# Altium schematic sheet I2C_PORT.Sch
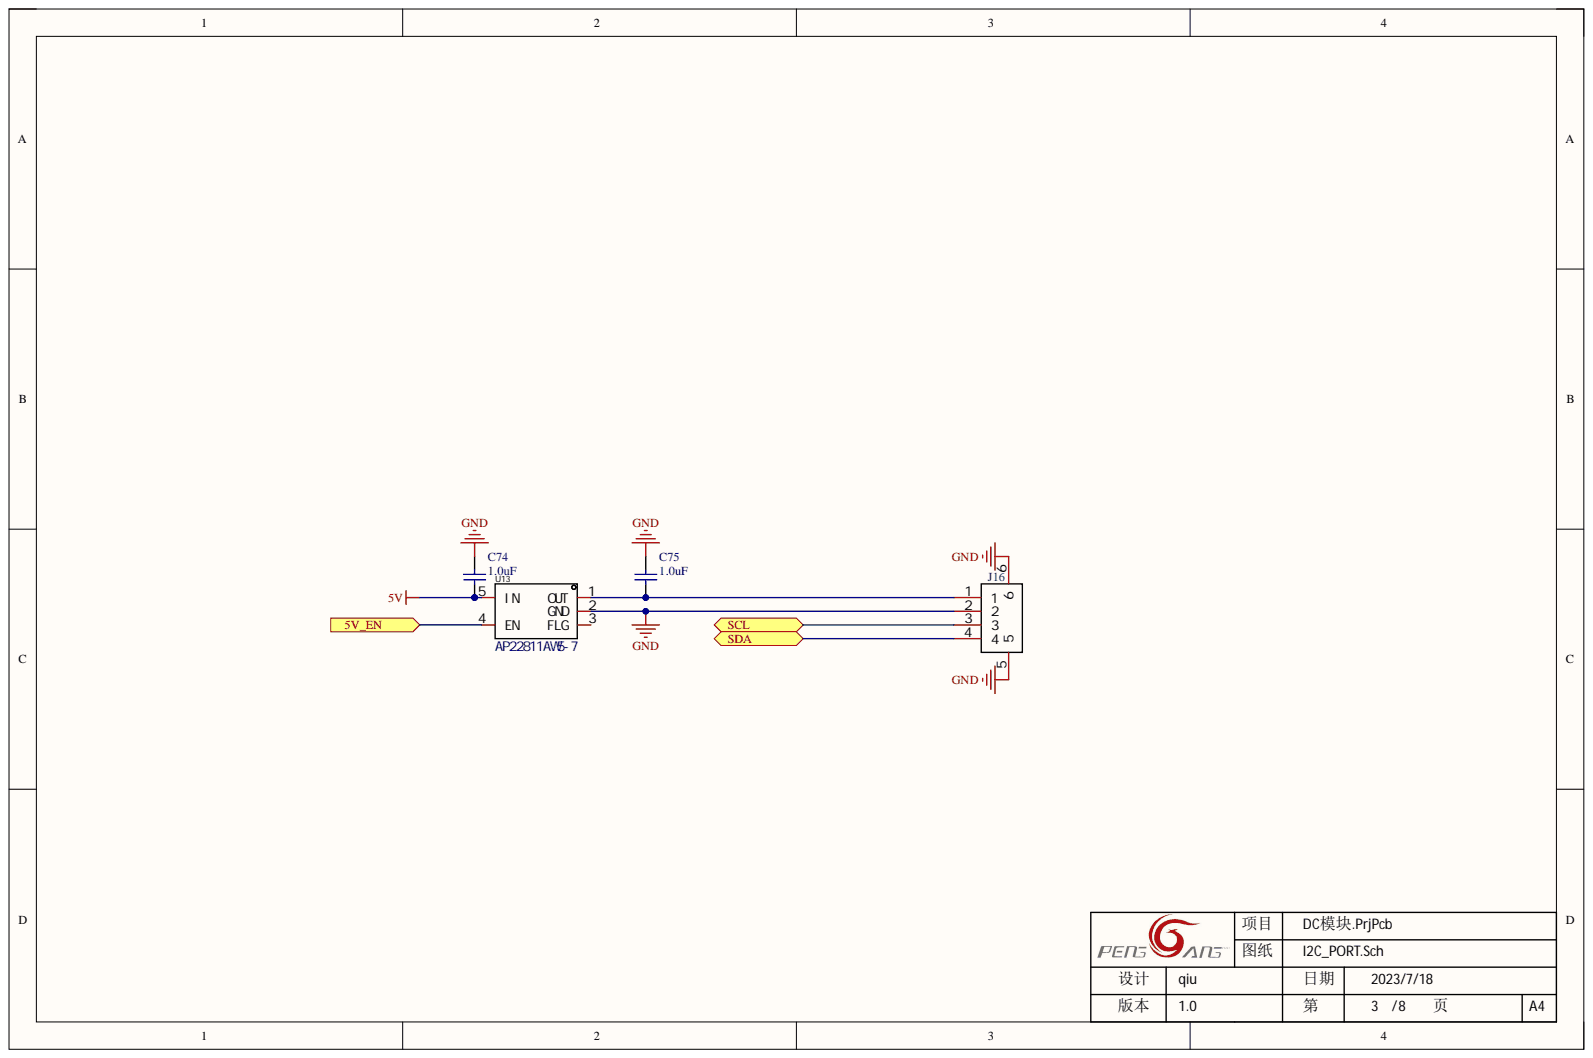
[diagram p0: I2C_PORT.Sch]

## Parts
C74  1.0uF  [0402_C]
C75  1.0uF  [0402_C]
J16  SM04B-GHS-TB(LF)(SN)  [CONN-SMD_4P-P1.25_SM04B-GHS-TB-LF-SN]  (value not drawn)
U13  AP22811AW5-7  [SOT-25-5_L3.0-W1.6-P0.95-LS2.8-BL]

## Ports
5V_EN, SCL, SDA

## Nets
5V: C74.2 U13.5(IN)
GND: C74.1 C75.1 J16.2 J16.5 J16.6 U13.2(GND)
5V_EN: U13.4(EN) ; port 5V_EN
SCL: J16.3 ; port SCL
SDA: J16.4 ; port SDA
NetC75_2: C75.2 J16.1 U13.1(OUT)

Not connected: U13 3(FLG)

## Library data of the parts
C74 C75 = 0402 1.0uF (105) 10% 25V: 贴片电容; Component Kind: Standard; ComponentLink1Description: 供应商链接; ComponentLink1URL: http://www.szlcsc.com/product/details_53938.html; Library Name: Miscellaneous Devices LC.IntLib; Package: 0402; Supplier: LC; SuppliersPartNumber: C52923
J16 = GH_SM04B-GHS-TB(LF)(SN): Manufacturer: JST; Add into BOM: yes; Number of PINs Per Row: 4; Pins Structure: 1x4P; Pitch: 1.25mm; Reference Series: GH; Component Kind: Standard; ComponentLink1Description: 供应商链接; ComponentLink1URL: https://item.szlcsc.com/207060.html; Contact Material: 磷青铜; Convert to PCB: yes; Datasheet: https://atta.szlcsc.com/upload/public/pdf/source/20180327/C189895_E152F1273677DB005E39DD42455B7B10.pdf; Device: SM04B-GHS-TB(LF)(SN); JLCPCB Part Class: Extended Part; LCSC Part Name: GH 1.25mm 1x4P; Library Name: mylib.SchLib; Manufacturer Part: SM04B-GHS-TB(LF)(SN); Mounting Style: -; Name: SM04B-GHS-TB(LF)(SN); Number of Rows: 1; Row Spacing: -; Supplier: LCSC; Supplier Footprint: SMD,P=1.25mm; SuppliersPartNumber: C189895; Symbol: SM04B-GHS-TB(LF)(SN)
U13 = AP22811AW5-7: Component Kind: Standard; ComponentLink1Description: 供应商链接; ComponentLink1URL: https://item.szlcsc.com/3485369.html; Library Name: mylib.SchLib; Supplier Footprint: SOT-25-5; SuppliersPartNumber: C3001660
